# Altium schematic sheet Bidirectional_PFC.SchDoc(Bidirectional_PFC)
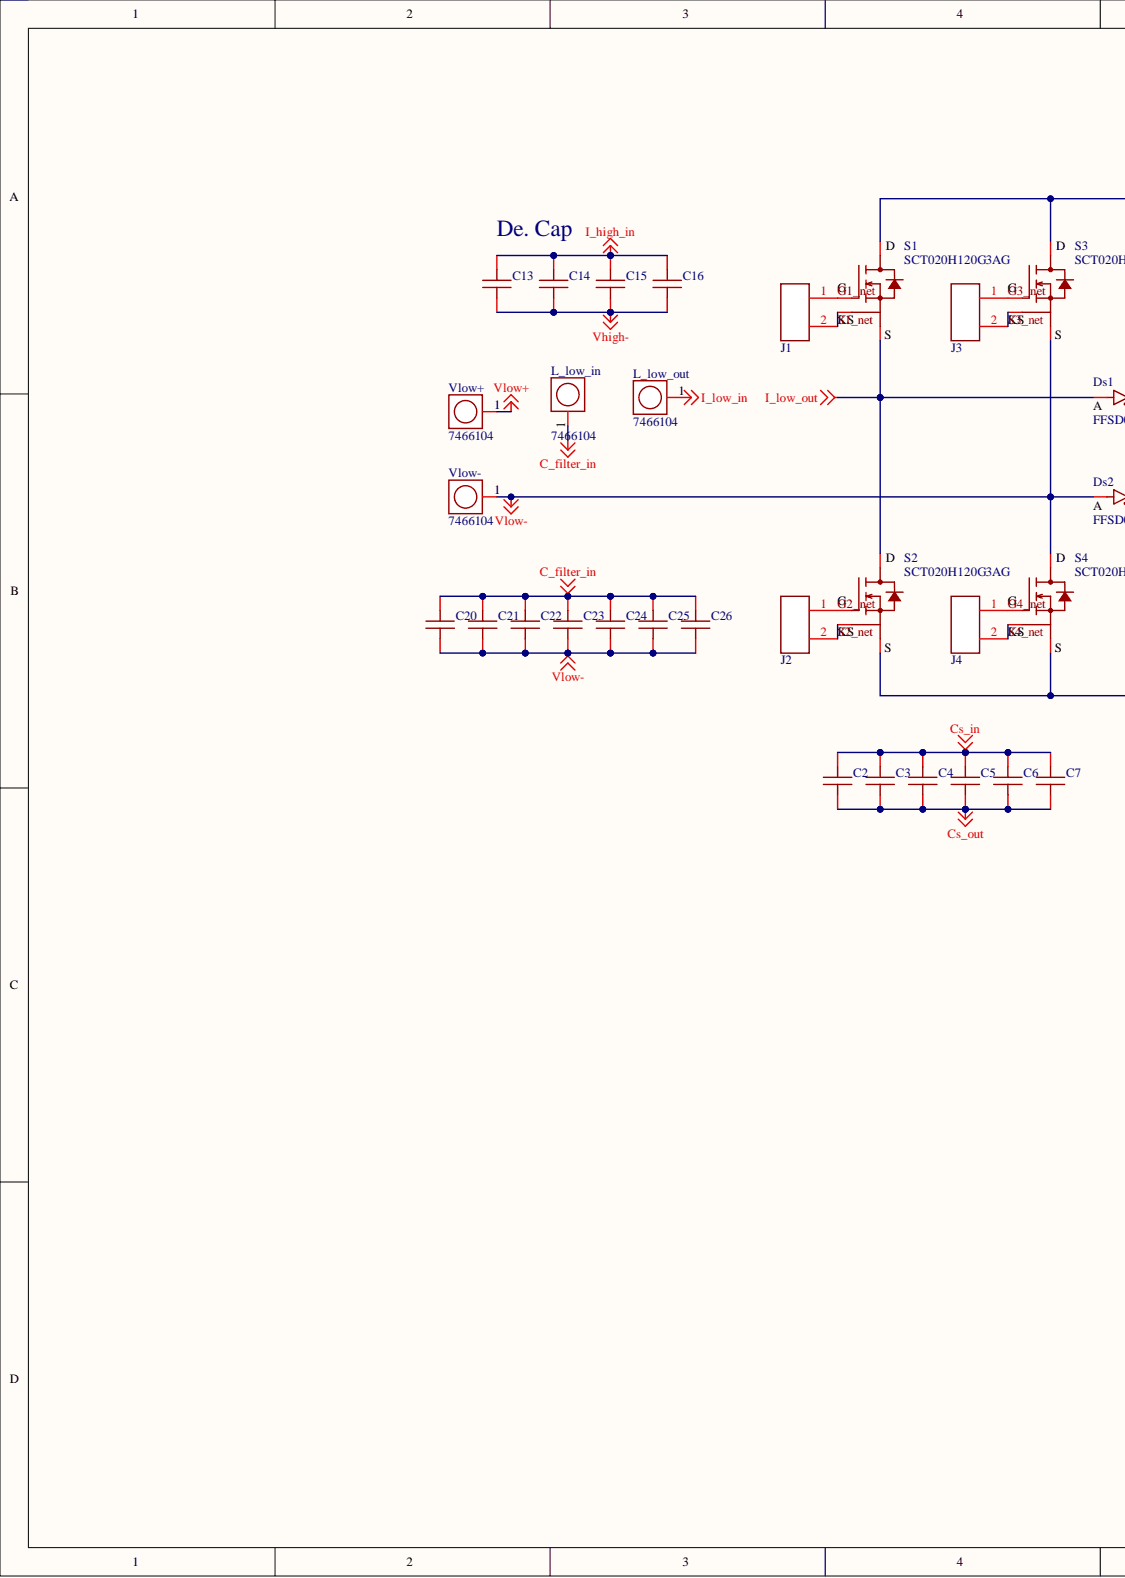
[diagram p0: Bidirectional_PFC.SchDoc, left]
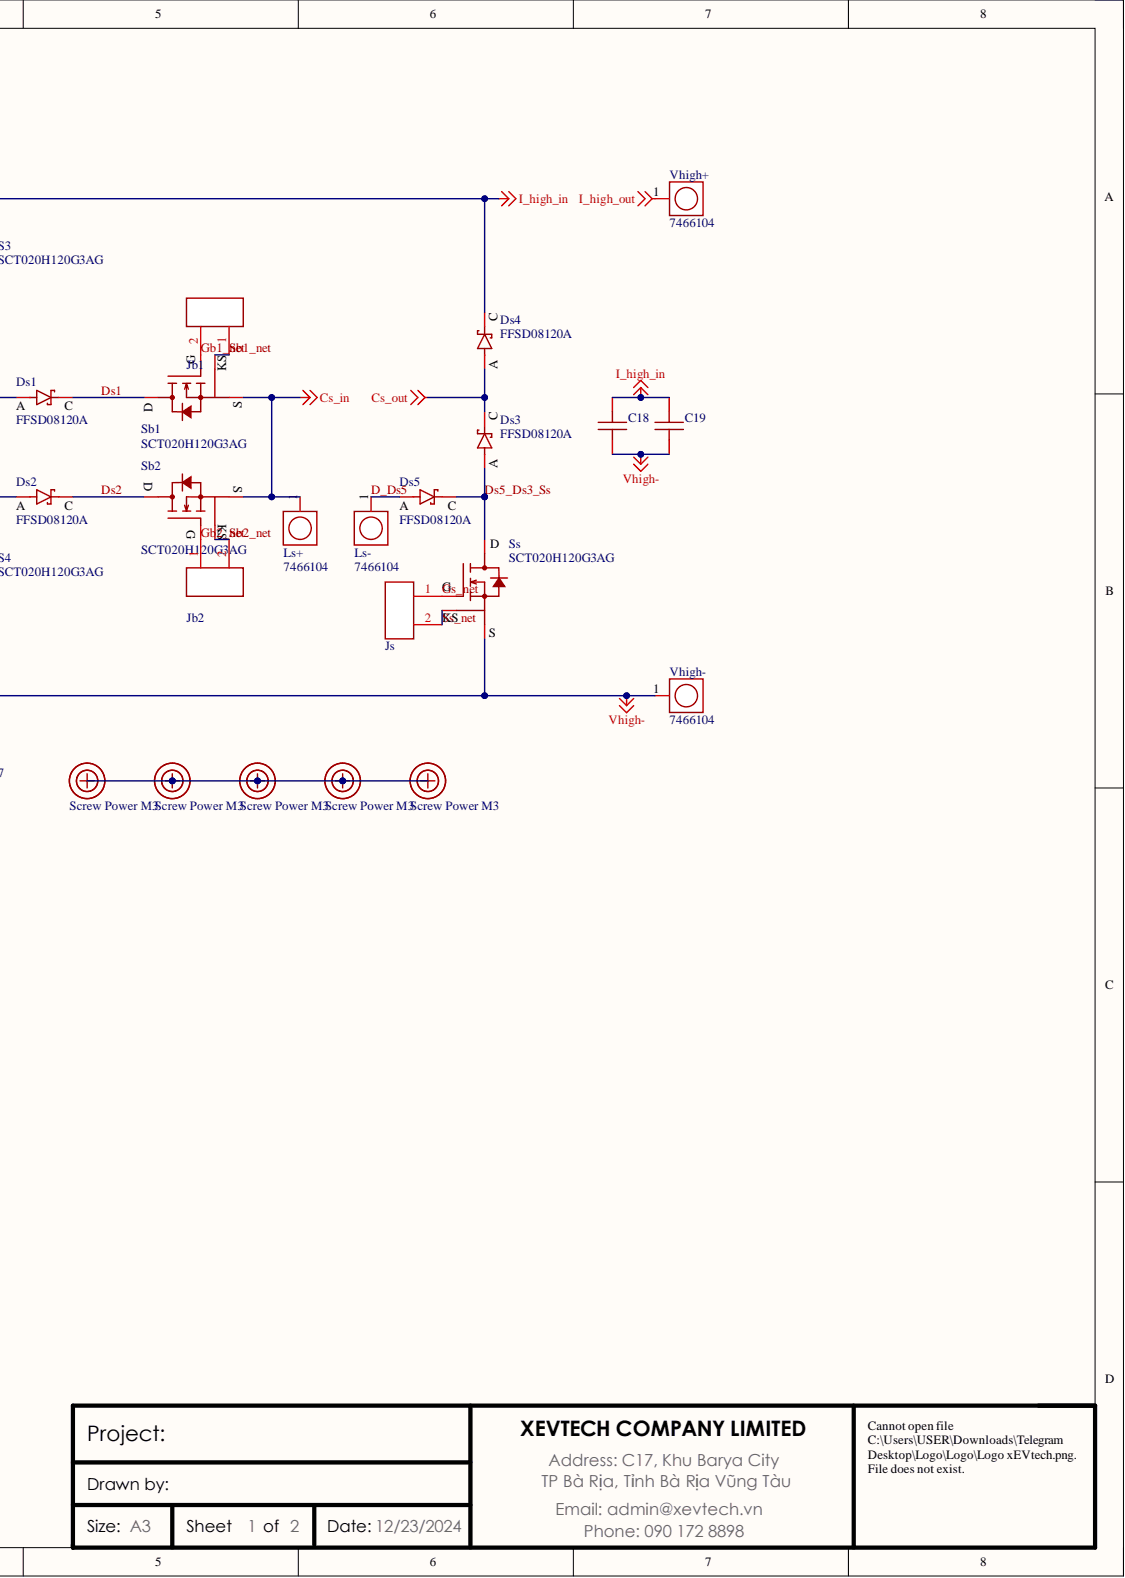
[diagram p1: Bidirectional_PFC.SchDoc, right]

## Parts
C2  GRM32D5C3A562JWA1K  [Cap 1210]  (value not drawn)
C3  GRM32D5C3A562JWA1K  [Cap 1210]  (value not drawn)
C4  GRM32D5C3A562JWA1K  [Cap 1210]  (value not drawn)
C5  GRM32D5C3A562JWA1K  [Cap 1210]  (value not drawn)
C6  GRM32D5C3A562JWA1K  [Cap 1210]  (value not drawn)
C7  GRM32D5C3A562JWA1K  [Cap 1210]  (value not drawn)
C13  C2220C104KDRACAUTO  [CAP 2220]  (value not drawn)
C14  C2220C104KDRACAUTO  [CAP 2220]  (value not drawn)
C15  C2220C104KDRACAUTO  [CAP 2220]  (value not drawn)
C16  C2220C104KDRACAUTO  [CAP 2220]  (value not drawn)
C18  C2220C104KDRACAUTO  [CAP 2220]  (value not drawn)
C19  C2220C104KDRACAUTO  [CAP 2220]  (value not drawn)
C20  C4532X7T2J304K250KA  [Cap 1812]  (value not drawn)
C21  C4532X7T2J304K250KA  [Cap 1812]  (value not drawn)
C22  C4532X7T2J304K250KA  [Cap 1812]  (value not drawn)
C23  C4532X7T2J304K250KA  [Cap 1812]  (value not drawn)
C24  C4532X7T2J304K250KA  [Cap 1812]  (value not drawn)
C25  C4532X7T2J304K250KA  [Cap 1812]  (value not drawn)
C26  C4532X7T2J304K250KA  [Cap 1812]  (value not drawn)
Ds1  FFSD08120A  [Diode TO 252 2]
Ds2  FFSD08120A  [Diode TO 252 2]
Ds3  FFSD08120A  [Diode TO 252 2]
Ds4  FFSD08120A  [Diode TO 252 2]
Ds5  FFSD08120A  [Diode TO 252 2]
J1  FTR-102-03-S-S  [header male smd 2pin-127_2]  (value not drawn)
J2  FTR-102-03-S-S  [header male smd 2pin-127_2]  (value not drawn)
J3  FTR-102-03-S-S  [header male smd 2pin-127_2]  (value not drawn)
J4  FTR-102-03-S-S  [header male smd 2pin-127_2]  (value not drawn)
Jb1  FTR-102-03-S-S  [header male smd 2pin-127_2]  (value not drawn)
Jb2  FTR-102-03-S-S  [header male smd 2pin-127_2]  (value not drawn)
Js  FTR-102-03-S-S  [header male smd 2pin-127_2]  (value not drawn)
L_low_in  7466104  [7466104]
L_low_out  7466104  [7466104]
Ls+  7466104  [7466104]
Ls-  7466104  [7466104]
S1  SCT020H120G3AG  [TO 263 7]
S2  SCT020H120G3AG  [TO 263 7]
S3  SCT020H120G3AG  [TO 263 7]
S4  SCT020H120G3AG  [TO 263 7]
Sb1  SCT020H120G3AG  [TO 263 7]
Sb2  SCT020H120G3AG  [TO 263 7]
Scew1  Screw Power M3  [Screw M3]
Scew2  Screw Power M3  [Screw M3]
Scew3  Screw Power M3  [Screw M3]
Scew4  Screw Power M3  [Screw M3]
Scew5  Screw Power M3  [Screw M3]
Ss  SCT020H120G3AG  [TO 263 7]
Vhigh+  7466104  [7466104]
Vhigh-  7466104  [7466104]
Vlow+  7466104  [7466104]
Vlow-  7466104  [7466104]

## Nets
C_filter_in: C20.1 C21.1 C22.1 C23.1 C24.1 C25.1 C26.1 L_low_in.1
Cs_in: C2.1 C3.1 C4.1 C5.1 C6.1 C7.1 Ls+.1 Sb1.3 Sb2.3
Cs_out: C2.2 C3.2 C4.2 C5.2 C6.2 C7.2 Ds3.1 Ds4.2
I_high_in: C13.1 C14.1 C15.1 C16.1 C18.1 C19.1 Ds4.1 S1.4 S3.4
I_high_out: Vhigh+.1
I_low_in: L_low_out.1
I_low_out: Ds1.2 S1.3 S2.4
Vhigh-: C13.2 C14.2 C15.2 C16.2 C18.2 C19.2 S2.3 S4.3 Ss.3 Vhigh-.1
Vlow+: Vlow+.1
Vlow-: C20.2 C21.2 C22.2 C23.2 C24.2 C25.2 C26.2 Ds2.2 S3.3 S4.4 Vlow-.1
D_Ds5: Ds5.2 Ls-.1
Ds1: Ds1.1 Sb1.4
Ds2: Ds2.1 Sb2.4
Ds5_Ds3_Ss: Ds3.2 Ds5.1 Ss.4
G1_net: J1.1 S1.1
G2_net: J2.1 S2.1
G3_net: J3.1 S3.1
G4_net: J4.1 S4.1
Gb1_net: Jb1.2 Sb1.1
Gb2_net: Jb2.1 Sb2.1
Gs_net: Js.1 Ss.1
S1_net: J1.2 S1.2
S2_net: J2.2 S2.2
S3_net: J3.2 S3.2
S4_net: J4.2 S4.2
Sb1_net: Jb1.1 Sb1.2
Sb2_net: Jb2.2 Sb2.2
Ss_net: Js.2 Ss.2
NetScew1_5: Scew1.5 Scew2.5 Scew3.5 Scew4.5 Scew5.5

## Library data of the parts
C2-C7 = Cap 1210 560pF: CAP CER 5600PF 1KV C0G/NP0 1210; Manufacturer: Murata; Value: 1210 560pF 1kV; Category: Ceramic Capacitors; Component Kind: Standard; Manufacturer Part Number: GRM32D5C3A562JWA1K; Pricing 1: 1=1.2, 10=0.9, 50=0.821, 100=0.6726, 500=0.53686, 1000=0.4737 (USD); RoHS: No; Stock 1: 3700; Supplier 1: Digikey; Supplier Part Number 1: 490-GRM32D5C3A562JWA1KCT-ND; Library Name: xEV_SmartOBC.SchLib
C13-C16 C18 C19 = CAP_2220_Clamp_1000V: 0.1 µF ±10% 1000V (1kV) Ceramic Capacitor X7R 2220 (5750 Metric); Manufacturer 1: KEMET; Category 1: Ceramic Capacitors; Description 1: Cap Ceramic 0.1uF 1000V X7R 10% Pad SMD 2220 125C Automotive T/R; Parameter 1: 0.1uF 1000V; Component Kind: Standard; ComponentLink1Description: http://www.mouser.com/ds/2/212/KEM_C1010_X7R_HV_SMD-1102742.pdf?src-supplier=Mouser; ComponentLink1URL: http://www.mouser.com/ds/2/212/KEM_C1010_X7R_HV_SMD-1102742.pdf?src-supplier=Mouser; ComponentLink2Description: http://www.farnell.com/datasheets/2747456.pdf?src-supplier=Farnell; ComponentLink2URL: http://www.farnell.com/datasheets/2747456.pdf?src-supplier=Farnell; ComponentLink3Description: http://www.farnell.com/datasheets/2747456.pdf?src-supplier=Newark; ComponentLink3URL: http://www.farnell.com/datasheets/2747456.pdf?src-supplier=Newark; ComponentLink4Description: https://datasheet.octopart.com/C1812C104KDRACAUTO-KEMET-datasheet-112121230.pdf?src-supplier=Newark; ComponentLink4URL: https://datasheet.octopart.com/C1812C104KDRACAUTO-KEMET-datasheet-112121230.pdf?src-supplier=Newark; ComponentLink5Description: https://api.kemet.com/component-edge/download/specsheet/C1812C104KDRACAUTO.pdf?src-supplier=Digi-Key; ComponentLink5URL: https://api.kemet.com/component-edge/download/specsheet/C1812C104KDRACAUTO.pdf?src-supplier=Digi-Key; ComponentLink6Description: https://api.kemet.com/component-edge/download/datasheet/C1812C104KDRACAUTO.pdf?src-supplier=Digi-Key; ComponentLink6URL: https://api.kemet.com/component-edge/download/datasheet/C1812C104KDRACAUTO.pdf?src-supplier=Digi-Key; ComponentLink7Description: https://api.kemet.com/component-edge/download/datasheet/C2220C104KDRACAUTO.pdf?src-supplier=Digi-Key; ComponentLink7URL: https://api.kemet.com/component-edge/download/datasheet/C2220C104KDRACAUTO.pdf?src-supplier=Digi-Key; Manufacturer Part Number 1: C2220C104KDRACAUTO; Pricing 1: 1=1,14, 10=0,9, 50=0,7798, 100=0,6748 (USD); RoHS 1: Yes; Stock 1: 8701; Supplier 1: Digi-Key; Supplier Part Number 1: 399-16808-1-ND; Library Name: xEV_SmartOBC.SchLib
C20-C26 = Cap 1812 0.3uF: Multilayer Ceramic Capacitor, 0.3 uF, 630 V, ± 10%, X7T, 1812 [4532 Metric]; Manufacturer: TDK; Capacitance: 300nF; Material: 4241ConductorMaterial; Value: 1812 0.3uF 630V; Voltage Rating: 630V; Case Code (Imperial): 1812; Case Code (Metric): 4532; Case/Package: 1812; Category: Ceramic Capacitors; Component Kind: Standard; ComponentLink1Description: http://www.mouser.com/ds/2/400/lcc_commercial_highvoltage_en-844071.pdf?src-supplier=Mouser; ComponentLink1URL: http://www.mouser.com/ds/2/400/lcc_commercial_highvoltage_en-844071.pdf?src-supplier=Mouser; ComponentLink2Description: https://product.tdk.com/info/en/catalog/spec/mlccspec_commercial_general_midvoltage_en.pdf?src-supplier=Digikey; ComponentLink2URL: https://product.tdk.com/info/en/catalog/spec/mlccspec_commercial_general_midvoltage_en.pdf?src-supplier=Digikey; ComponentLink3Description: http://product.tdk.com/en/catalog/datasheets/mlcc_commercial_midvoltage_en.pdf?src-supplier=Avnet; ComponentLink3URL: http://product.tdk.com/en/catalog/datasheets/mlcc_commercial_midvoltage_en.pdf?src-supplier=Avnet; Dielectric: X7T; Height: 2.5mm; Lead Free: Lead Free; Length: 0.177inch; Manufacturer Part Number: C4532X7T2J304K250KA; Max Operating Temperature: 125°C; Min Operating Temperature: -55°C; Mount: Surface Mount; Package Quantity: 1; Packaging: Tape and Reel; Pricing 1: 1=0.89, 10=0.668, 50=0.6092, 100=0.4991 (USD); RoHS: Yes; RoHS Compliant: Yes; Series: C; Stock 1: 45711; Supplier 1: Digikey; Supplier Part Number 1: 445-13458-1-ND; Termination: SMD/SMT; Thickness: 0.11inch; Tolerance: 10%; Voltage Rating (DC): 630V; Width: 0.126inch; Library Name: xEV_SmartOBC.SchLib
Ds1-Ds5 = FFSD08120A: Rectifier Diode, Avalanche, 1 Phase, 1 Element, 22.5A, 1200V V(RRM), Silicon Carbide, TO-252AA; Manufacturer: ON Semiconductor; Max Reverse Voltage (DC): 1.2kV; Reverse Recovery Time: 0ns; Average Rectified Current: 22.5A; Case/Package: TO-252-3; Component Kind: Standard; ComponentLink1Description: http://www.onsemi.com/pub/Collateral/FFSD08120A-D.PDF?src-supplier=Digikey; ComponentLink1URL: http://www.onsemi.com/pub/Collateral/FFSD08120A-D.PDF?src-supplier=Digikey; ComponentLink2Description: https://datasheet.octopart.com/FFSD08120A-ON-Semiconductor-datasheet-139010672.pdf?src-supplier=Future+Electronics; ComponentLink2URL: https://datasheet.octopart.com/FFSD08120A-ON-Semiconductor-datasheet-139010672.pdf?src-supplier=Future+Electronics; Manufacturer Part Number: FFSD08120A; Mount: Surface Mount; Packaging: Cut Tape; Pricing 1: 2500=2.65 (USD); RoHS: Yes; RoHS Compliant: Yes; Series: -; Stock 1: 2500; Supplier 1: Future Electronics; Supplier Part Number 1: 2178100; Library Name: xEV_SmartOBC.SchLib
J1-J4 Jb1 Jb2 Js = Header male SM 2p 1.27mm_2: Connector Header Surface Mount 2 position 0.050" (1.27mm); Manufacturer 1: Samtec; Category 1: Connectors; Description 1: Headers & Wire Housings Surface Mount Micro Header, 0.050 x 0.100 Pitch; Component Kind: Standard; ComponentLink1Description: http://www.farnell.com/datasheets/1810770.pdf?src-supplier=Farnell; ComponentLink1URL: http://www.farnell.com/datasheets/1810770.pdf?src-supplier=Farnell; ComponentLink2Description: https://datasheet.octopart.com/FTR-102-03-S-S-Samtec-datasheet-13696950.pdf?src-supplier=Newark; ComponentLink2URL: https://datasheet.octopart.com/FTR-102-03-S-S-Samtec-datasheet-13696950.pdf?src-supplier=Newark; ComponentLink3Description: https://datasheet.octopart.com/FTR-102-03-S-S-Samtec-datasheet-24969242.pdf?src-supplier=Farnell; ComponentLink3URL: https://datasheet.octopart.com/FTR-102-03-S-S-Samtec-datasheet-24969242.pdf?src-supplier=Farnell; ComponentLink4Description: http://www.samtec.com/documents/webfiles/pdf/FTR_SMT.pdf?src-supplier=Avnet; ComponentLink4URL: http://www.samtec.com/documents/webfiles/pdf/FTR_SMT.pdf?src-supplier=Avnet; ComponentLink5Description: https://datasheet.octopart.com/FTR-102-03-S-S-Samtec-datasheet-136395375.pdf?src-supplier=Toby+Electronics; ComponentLink5URL: https://datasheet.octopart.com/FTR-102-03-S-S-Samtec-datasheet-136395375.pdf?src-supplier=Toby+Electronics; ComponentLink6Description: https://datasheet.octopart.com/FTR-102-03-S-S-Samtec-datasheet-151312691.pdf?src-supplier=Sager+Electronics; ComponentLink6URL: https://datasheet.octopart.com/FTR-102-03-S-S-Samtec-datasheet-151312691.pdf?src-supplier=Sager+Electronics; ComponentLink7Description: https://datasheet.octopart.com/FTR-102-03-S-S-Samtec-datasheet-97028732.pdf?src-supplier=Samtec; ComponentLink7URL: https://datasheet.octopart.com/FTR-102-03-S-S-Samtec-datasheet-97028732.pdf?src-supplier=Samtec; Manufacturer Part Number 1: FTR-102-03-S-S; Pricing 1: 1=0,97, 10=0,817, 100=0,7333, 1000=0,53328, 5000=0,46662, 10000=0,44329 (USD); RoHS 1: Yes; Stock 1: 4034; Supplier 1: Digikey; Supplier Part Number 1: 612-FTR-102-03-S-S-ND; Value: Header Male; Library Name: xEV_SmartOBC.SchLib
L_low_in L_low_out Ls+ Ls- Vhigh+ Vhigh- Vlow+ Vlow- = Ter_7466104: 1 Pin Screw Terminal, Power Tap M4 Surface Mount; Manufacturer 1: Wurth Electronics; Category 1: Terminals; Description 1: WP-SMBU REDCUBE Bush, SMT_closed_pin; Component Kind: Standard; ComponentLink1Description: https://katalog.we-online.de/em/datasheet/7466005R.pdf?src-supplier=Digi-Key; ComponentLink1URL: https://katalog.we-online.de/em/datasheet/7466005R.pdf?src-supplier=Digi-Key; ComponentLink2Description: https://datasheet.ciiva.com/29669/7466104-29669839.pdf?src-supplier=Digikey; ComponentLink2URL: https://datasheet.ciiva.com/29669/7466104-29669839.pdf?src-supplier=Digikey; ComponentLink3Description: https://datasheet.ciiva.com/46408/1804171-46408791.pdf?src-supplier=Element14; ComponentLink3URL: https://datasheet.ciiva.com/46408/1804171-46408791.pdf?src-supplier=Element14; Manufacturer Part Number 1: 7466104; Pricing 1: 1=2,64, 10=2,507, 50=2,268, 100=2,03, 500=1,839 (USD); RoHS 1: Yes; Stock 1: 953; Supplier 1: Digikey; Supplier Part Number 1: 732-5243-ND; Library Name: xEV_SmartOBC.SchLib
S1-S4 Sb1 Sb2 Ss = SCT020H120G3AG: Automotive-grade silicon carbide Power MOSFET 1200 V, 18.5 mOhm typ., 100 A in an H2PAK-7 package; Manufacturer 1: STMicroelectronics; Category 1: Uncategorized; Description 1: Automotive Silicon Carbide Power MOSFET 1200 V, 100A20 m; Component Kind: Standard; Manufacturer Part Number 1: SCT020H120G3AG; Pricing 1: 1=21, 10=20,67, 40=20,46, 150=20,27, 500=20 (USD); RoHS 1: No; Stock 1: 285; Supplier 1: Future Electronics; Supplier Part Number 1: 3176258; Library Name: xEV_SmartOBC.SchLib
Scew1-Scew5 = Screw Power M3: Component Kind: Standard; Library Name: xEV_SmartOBC.SchLib
